# Altium schematic sheet Relay_IO.SchDoc
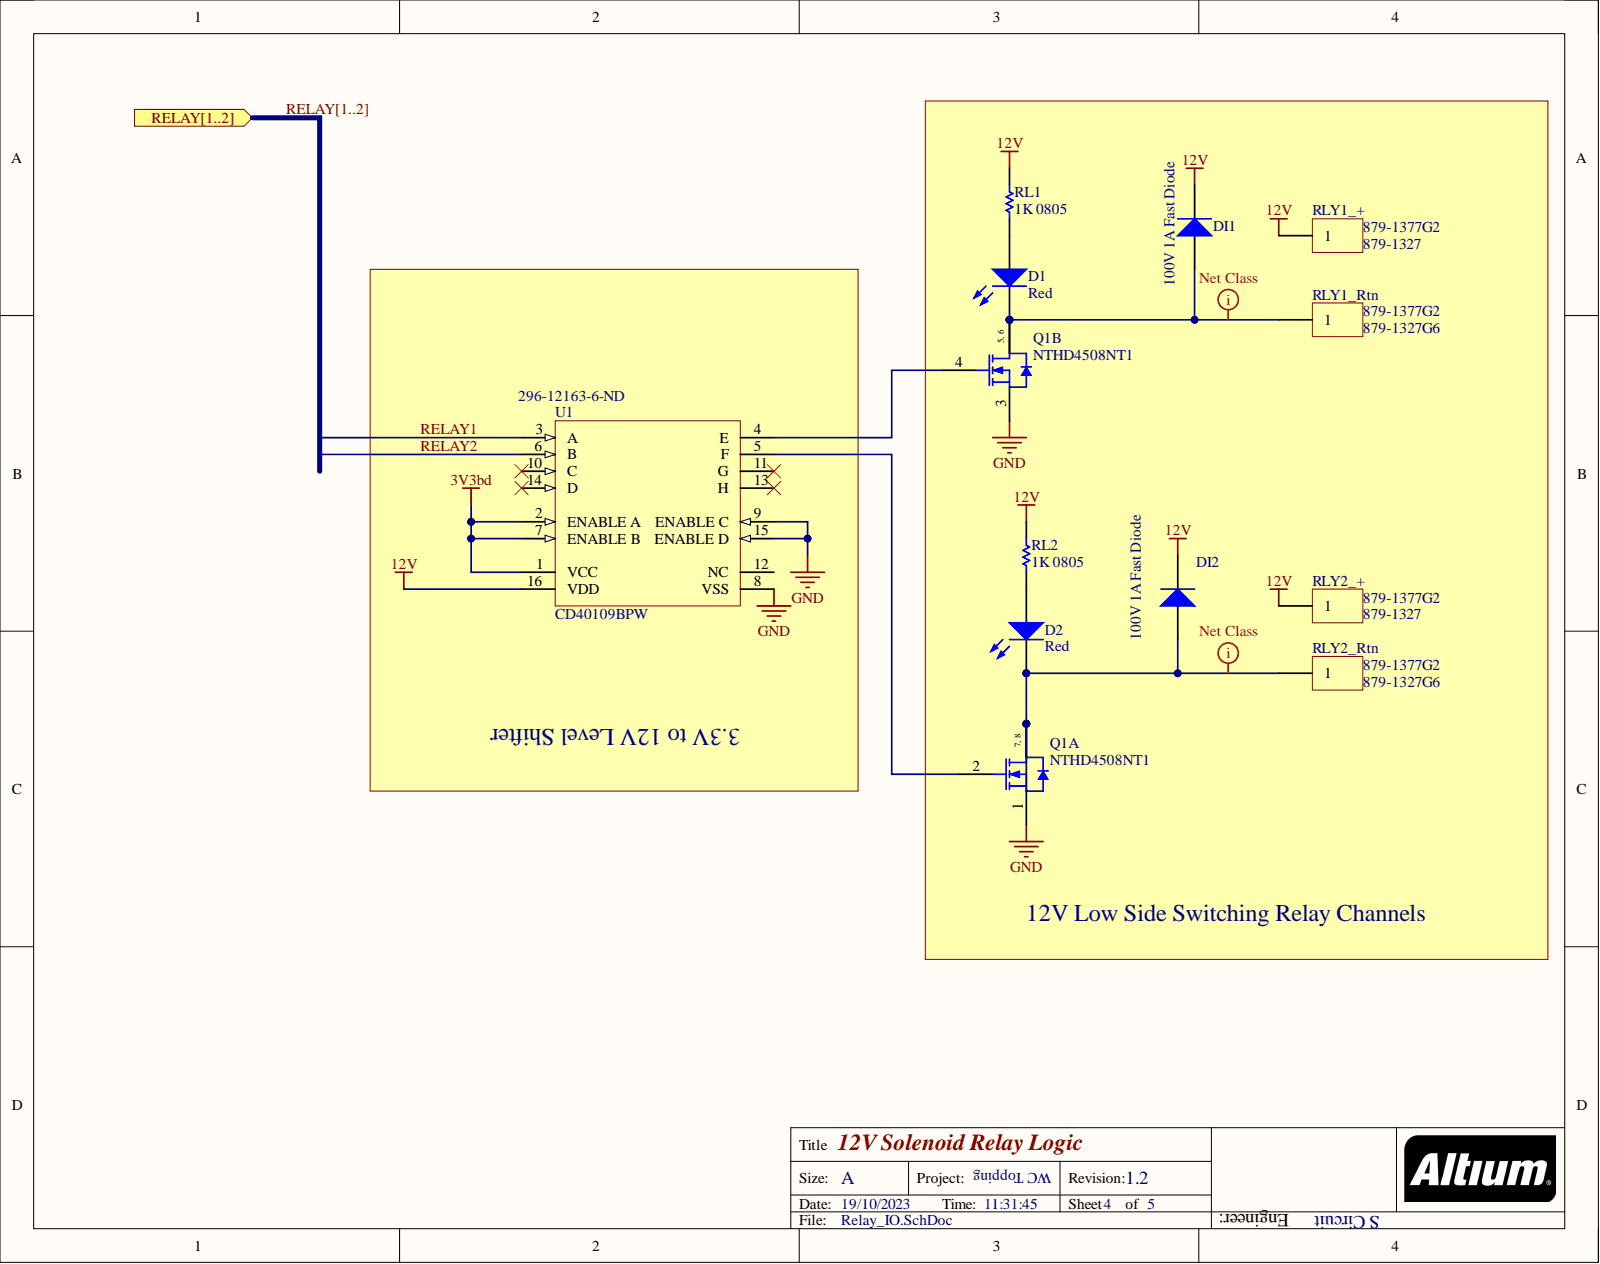
[diagram p0: Relay_IO.SchDoc]

## Parts
D1  Color: Red  [0603_A]  (hidden comment: LED Red)
D2  Color: Red  [0603_A]  (hidden comment: LED Red)
DI1  100V 1A Fast Diode  Forward Current If(AV): 1A  Repetitive Reverse Voltage Vrrm Max: 100V  [DO-35]
DI2  100V 1A Fast Diode  Forward Current If(AV): 1A  Repetitive Reverse Voltage Vrrm Max: 100V  [DO-35]
Q1  NTHD4508NT1  [ONSC-CHIPFET-8-1206A-03_S2_V]  (parts A,B)
RL1  1K 0805  Supplier Device Package: 0805  [0805_L]
RL2  1K 0805  Supplier Device Package: 0805  [0805_L]
RLY1_+  Supplier Part Number 1: 879-1377G2  Supplier Part Number 2: 879-1327  [Anderson_Red_RHT_BOT]  (hidden comment: Anderson-1Pin RED_PCB_RHT)
RLY1_Rtn  Mouser: 879-1327G6  Supplier Part Number 1: 879-1377G2  [Anderson_BLK_RHT_BOT]  (hidden comment: Anderson-1Pin BLK_PCB_RHT)
RLY2_+  Supplier Part Number 1: 879-1377G2  Supplier Part Number 2: 879-1327  [Anderson_Red_RHT_BOT]  (hidden comment: Anderson-1Pin RED_PCB_RHT)
RLY2_Rtn  Mouser: 879-1327G6  Supplier Part Number 1: 879-1377G2  [Anderson_BLK_RHT_BOT]  (hidden comment: Anderson-1Pin BLK_PCB_RHT)
U1  CD40109BPW  Supplier Part Number 1: 296-12163-6-ND  [PW0016A_M]

## Ports
RELAY1, RELAY2, RELAY[1..2]

## Nets
3V3bd: U1.1(VCC) U1.2(ENABLE_A) U1.7(ENABLE_B)
12V: DI1.2 DI2.2 RL1.2 RL2.2 RLY1_+.1 RLY2_+.1 U1.16(VDD)
GND: Q1.1 Q1.3 U1.8(VSS) U1.9(ENABLE_C) U1.15(ENABLE_D)
RELAY1: U1.3(A) ; port RELAY[1..2]  [also labelled RELAY]
RELAY2: U1.6(B) ; port RELAY[1..2]  [also labelled RELAY]
NetD1_1: D1.1 DI1.1 Q1.5 Q1.6 RLY1_Rtn.1
NetD1_2: D1.2 RL1.1
NetD2_1: D2.1 DI2.1 Q1.7 Q1.8 RLY2_Rtn.1
NetD2_2: D2.2 RL2.1
NetQ1_2: Q1.2 U1.5(F)
NetQ1_4: Q1.4 U1.4(E)

Not connected: U1 10(C) 11(G) 12(NC) 13(H) 14(D)

## Library data of the parts
D1 D2 = LED_Red0603: SMD mono-color Chip LED, WL-SMCW, Red; Manufacturer: Wurth Electronics Inc; Manufacturer Part Number: 150060RS75000; Current - Test: 20mA; Lens Color: Colorless; Lens Style/Size: Rectangle with Flat Top, 1.10mm x 0.70mm; Lens Transparency: Clear; Millicandela Rating: 250mcd; Viewing Angle: 140°; Voltage - Forward (Vf) (Typ): 2V; Wavelength - Dominant: 625nm; Wavelength - Peak: 630nm; Category: Optoelectronics; Component Kind: Standard; ComponentLink1Description: http://katalog.we-online.de/led/datasheet/150060RS75000.pdf; ComponentLink1URL: http://katalog.we-online.de/led/datasheet/150060RS75000.pdf; Features: -; Height (Max): 0.80mm; Mounting Type: Surface Mount; Package / Case: 0603 (1608 Metric); Packaging: Cut Tape (CT); Pricing 1: 1=0.24, 50=0.21, 100=0.192, 500=0.174, 1000=0.162 (USD); RoHS: RoHS Compliant; Size / Dimension: 1.60mm L x 0.80mm W; Stock 1: 22059; Supplier 1: Digi-Key; Supplier Device Package: 0603; Supplier Part Number 1: 732-4978-1-ND; Library Name: WCT.IntLib
DI1 DI2 = Diode 1N4148: High Conductance Fast Diode; Manufacturer: ON Semiconductor; Manufacturer Part Number: MUR110RLG; Diode Case Style: DO-204AL; Diode Configuration: Single; Diode Type: Fast Recovery; Forward Surge Current Ifsm Max: 35A; Forward Voltage VF Max: 1.25V; No. of Pins: 2; Reverse Recovery Time trr Max: 75ns; SVHC: No SVHC (16-Jun-2014); Component Kind: Standard; ComponentLink1Description: https://datasheet.ciiva.com/915/mur120-d-915616.pdf; ComponentLink1URL: https://datasheet.ciiva.com/915/mur120-d-915616.pdf; ComponentLink2Description: https://datasheet.ciiva.com/30479/0900766b812212ee-30479481.pdf; ComponentLink2URL: https://datasheet.ciiva.com/30479/0900766b812212ee-30479481.pdf; ComponentLink3Description: https://datasheet.ciiva.com/25950/mur120-d-25950702.pdf; ComponentLink3URL: https://datasheet.ciiva.com/25950/mur120-d-25950702.pdf; ComponentLink4Description: https://datasheet.ciiva.com/16474/mur120-d-16474333.pdf; ComponentLink4URL: https://datasheet.ciiva.com/16474/mur120-d-16474333.pdf; ComponentLink5Description: https://datasheet.ciiva.com/915/mur120-d-915618.pdf; ComponentLink5URL: https://datasheet.ciiva.com/915/mur120-d-915618.pdf; ComponentLink6Description: https://datasheet.ciiva.com/915/mur120-d-915619.pdf; ComponentLink6URL: https://datasheet.ciiva.com/915/mur120-d-915619.pdf; ComponentLink7Description: https://datasheet.ciiva.com/16208/mur120-d-16208137.pdf; ComponentLink7URL: https://datasheet.ciiva.com/16208/mur120-d-16208137.pdf; Operating Temperature Max: 175°C; Packaging: Each; Pricing 1: 1=0.48, 10=0.362, 100=0.2254, 500=0.1541, 1000=0.1185 (USD); Signal Integrity: 1N4148; Simulation: 1N4148; Stock 1: 22453; Supplier 1: Ciiva; Supplier Part Number 1: MUR110RLGOSCT-ND; Library Name: WCT.IntLib
Q1 = NTHD4508NT1: Power MOSFET, 20 V, 4.1 A, Dual N-Channel, 8-Pin CHIPFET, Tape and Reel; Manufacturer: On Semiconductor; Manufacturer Part Number: NTHD4508NT1G; Channel Polarity: N Channel; Ciss Typ (pF): 180; Coss Typ (pF): 80; Crss Typ (pF): 25; Current - Continuous Drain (Id) @ 25°C: 3A; Drain to Source Voltage (Vdss): 20V; FET Feature: Logic Level Gate; FET Type: 2 N-Channel (Dual); Gate Charge (Qg) @ Vgs: 4nC @ 4.5V; ID Max (A): 4.1; Input Capacitance (Ciss) @ Vds: 180pF @ 10V; Power - Max: 1.13W; Qrr Typ (nC): 6; Rds On (Max) @ Id, Vgs: 75 mOhm @ 3.1A, 4.5V; V(BR)DSS Min (V): 20; VGS Max (V): 12; Vgs(th) (Max) @ Id: 1.2V @ 250µA; VGS(th) Max (V): 1.2; Category: Discrete Semiconductor Products; Component Kind: Standard; ComponentLink1Description: Manufacturer URL; ComponentLink1URL: http://www.onsemi.com/; ComponentLink2Description: Datasheet; ComponentLink2URL: http://www.onsemi.com/pub_link/Collateral/NTHD4508N-D.PDF; ComponentLink3Description: Package Specification; ComponentLink3URL: http://www.onsemi.com/pub_link/Collateral/NTHC5513-D.PDF; Configuration: Dual; DatasheetVersion: Rev. 3; Mounting Technology: Surface Mount; Mounting Type: Surface Mount; Operating Temperature: -55°C ~ 150°C (TJ); Package / Case: 8-SMD, Flat Lead; Package Type: ChipFET-8; PackageDescription: 8-Pin ChipFET, Body 3.025 x 1.625 mm, Pitch 0.635 mm; PackageReference: CHIPFET-8-1206A-03_S2; PackageVersion: Rev. E, 10/2004; Packaging: Cut Tape (CT); Packing: Tape and Reel; Part Status: Active; PartId: 1; PartNumber: NTHD4508NT1; Pricing 1: 1=0.53, 10=0.457, 100=0.3416, 500=0.26832, 1000=0.20685 (USD); RoHS: RoHS Compliant; Stock 1: 6850; Supplier 1: Digi-Key; Supplier Device Package: ChipFET™; Supplier Part Number 1: NTHD4508NT1GOSCT-ND; Library Name: WCT.IntLib
RL1 RL2 = Res1K_0805: Manufacturer: Panasonic Electronic Components; Manufacturer Part Number: ERJ-6GEYJ102V; Power (Watts): 0.125W, 1/8W; Temperature Coefficient: ±200ppm/°C; Tolerance: ±5%; Category: Resistors; Component Kind: Standard; ComponentLink1Description: http://industrial.panasonic.com/www-cgi/jvcr13pz.cgi?E+PZ+3+AOA0001+ERJ6GEYJ102V+7+WW; ComponentLink1URL: http://industrial.panasonic.com/www-cgi/jvcr13pz.cgi?E+PZ+3+AOA0001+ERJ6GEYJ102V+7+WW; Composition: Thick Film; Failure Rate: -; Features: Automotive AEC-Q200; Height: 0.028" (0.70mm); Number of Terminations: 2; Operating Temperature: -55°C ~ 155°C; Package / Case: 0805 (2012 Metric); Packaging: Cut Tape (CT); Part Status: Active; Pricing 1: 1=0.1, 50=0.015, 100=0.011, 250=0.0084, 500=0.00674, 1000=0.00496 (USD); Resistance (Ohms): 1k; RoHS: RoHS Compliant; Size / Dimension: 0.079" L x 0.049" W (2.00mm x 1.25mm); Stock 1: 1669380; Supplier 1: Digi-Key; Supplier Part Number 1: P1.0KACT-ND; Library Name: ICEdigikey.IntLib
RLY1_+ RLY2_+ = Anderson-1Pin RED_PCB_RHT: 1 pin Anderson; Manufacturer: Anderson Power Products (APP); Manufacturer Part Number: 1377G1, 1377G2, 1327-BK,137G6-BK; Current Rating: 25 A; Number of Positions / Contacts: 1; Voltage Rating: 600 V; Component Kind: Standard; ComponentLink1Description: http://components.arrow.com/part/dataview/0323_01933244; ComponentLink1URL: http://components.arrow.com/part/dataview/0323_01933244; ComponentLink2Description: http://www.andersonpower.com/files.php?file=CAT-PPMP(4).pdf; ComponentLink2URL: http://www.andersonpower.com/files.php?file=CAT-PPMP(4).pdf; Contact Material: Copper Alloy; Contact Plating: Tin; Mouser: 879-1327G6; Pricing 1: 1=1.14, 10=1.14, 50=0.947, 100=0.901, 1000=0.777, 10000=0.777 (USD); Product Type: Contacts; Series: PCB Powerpole; Stock 1: 233; Supplier 1: Mouser; Termination Style: Solder; Library Name: WCT.IntLib
RLY1_Rtn RLY2_Rtn = Anderson-1Pin BLK_PCB_RHT: 1 pin Anderson; Manufacturer: Anderson Power Products (APP); Manufacturer Part Number: 1377G1, 1377G2, 1327-BK,137G6-BK; Current Rating: 25 A; Number of Positions / Contacts: 1; Voltage Rating: 600 V; Component Kind: Standard; ComponentLink1Description: http://components.arrow.com/part/dataview/0323_01933244; ComponentLink1URL: http://components.arrow.com/part/dataview/0323_01933244; ComponentLink2Description: http://www.andersonpower.com/files.php?file=CAT-PPMP(4).pdf; ComponentLink2URL: http://www.andersonpower.com/files.php?file=CAT-PPMP(4).pdf; Contact Material: Copper Alloy; Contact Plating: Tin; Pricing 1: 1=1.14, 10=1.14, 50=0.947, 100=0.901, 1000=0.777, 10000=0.777 (USD); Product Type: Contacts; Series: PCB Powerpole; Stock 1: 233; Supplier 1: Mouser; Supplier Part Number 2: 879-1327G6; Termination Style: Solder; Library Name: WCT.IntLib
U1 = CMP-1527-00010-2: CMOS Quad Low-to-High Voltage Level Shifter, 3 to 18 V, -55 to 125 degC, 16-Pin TSSOP (PW16), RoHS, Tube; Manufacturer: Texas Instruments; Manufacturer Part Number: CD40109BPWR; Differential - Input: No/No; Dynamic Catalog: 4000B; Logic Function: Level Shifter, 3-State; Number of Bits: 4; Number of Outputs/Channel: 1; Other Names: 296-12163-6; Propagation Delay: 240 ns Max.; Propagation Delay (Max): 240ns; Voltage - Supply: 3 V ~ 18 V; VSS: 3 to 18 V; Category: Integrated Circuits (ICs); Component Kind: Standard; ComponentLink1Description: Manufacturer URL; ComponentLink1URL: www.ti.com; ComponentLink2Description: Datasheet; ComponentLink2URL: http://www.ti.com/lit/ds/symlink/cd40109b.pdf; ComponentLink3Description: Package Specification; ComponentLink3URL: http://www.ti.com/lit/ml/mpds361/mpds361.pdf; ComponentLink4Description: https://datasheet.ciiva.com/1899/cd40109b-1899236.pdf; ComponentLink4URL: https://datasheet.ciiva.com/1899/cd40109b-1899236.pdf; ComponentLink5Description: https://datasheet.ciiva.com/1899/cd40109b-1899237.pdf; ComponentLink5URL: https://datasheet.ciiva.com/1899/cd40109b-1899237.pdf; ComponentLink6Description: https://datasheet.ciiva.com/1899/cd40109b-1899238.pdf; ComponentLink6URL: https://datasheet.ciiva.com/1899/cd40109b-1899238.pdf; ComponentLink7Description: https://datasheet.ciiva.com/15096/getdatasheetpartid-50246-15096137.pdf; ComponentLink7URL: https://datasheet.ciiva.com/15096/getdatasheetpartid-50246-15096137.pdf; ComponentLink8Description: https://datasheet.ciiva.com/41455/001015415-da-01-en-ic-quad-lo-hi-v-cd40109bpwr-tssop-16-tid-41455340.pdf; ComponentLink8URL: https://datasheet.ciiva.com/41455/001015415-da-01-en-ic-quad-lo-hi-v-cd40109bpwr-tssop-16-tid-41455340.pdf; ComponentLink9Description: https://datasheet.ciiva.com/3305/cd40109b-mil-3305133.pdf; ComponentLink9URL: https://datasheet.ciiva.com/3305/cd40109b-mil-3305133.pdf; Data Rate: -; DatasheetVersion: SCHS099B; Family: Logic - Translators; Input Type: Voltage; Library Name: Altium Content Vault; Mounting Technology: Surface Mount; Number of Channels: 4; Number of Outputs: 4; Operating Temperature: -55°C ~ 125°C; Output Type: Voltage; Package / Case: 16-TSSOP (0.173", 4.40mm Width); Package Reference: PW0016A; PackageDescription: 16-Pin Plastic Small Outline Package, Body 5 x 4.4 mm, Pitch 0.65 mm; PackageVersion: 4040064-4/G; Packaging: Digi-Reel®; Packing: Tube; PartNumber: CD40109BPW; Pricing 1: 1=0.42, 10=0.36, 100=0.2688, 500=0.2111, 1000=0.1628 (USD); RoHS: TRUE; Series: 4000B; Standard Package: 1; Stock 1: 11025; Supplier 1: Digikey; Supplier Device Package: 16-TSSOP; Temperature Range: -55 to 125 degC
